# Altium schematic sheet CPLD-V MAIN.SchDoc
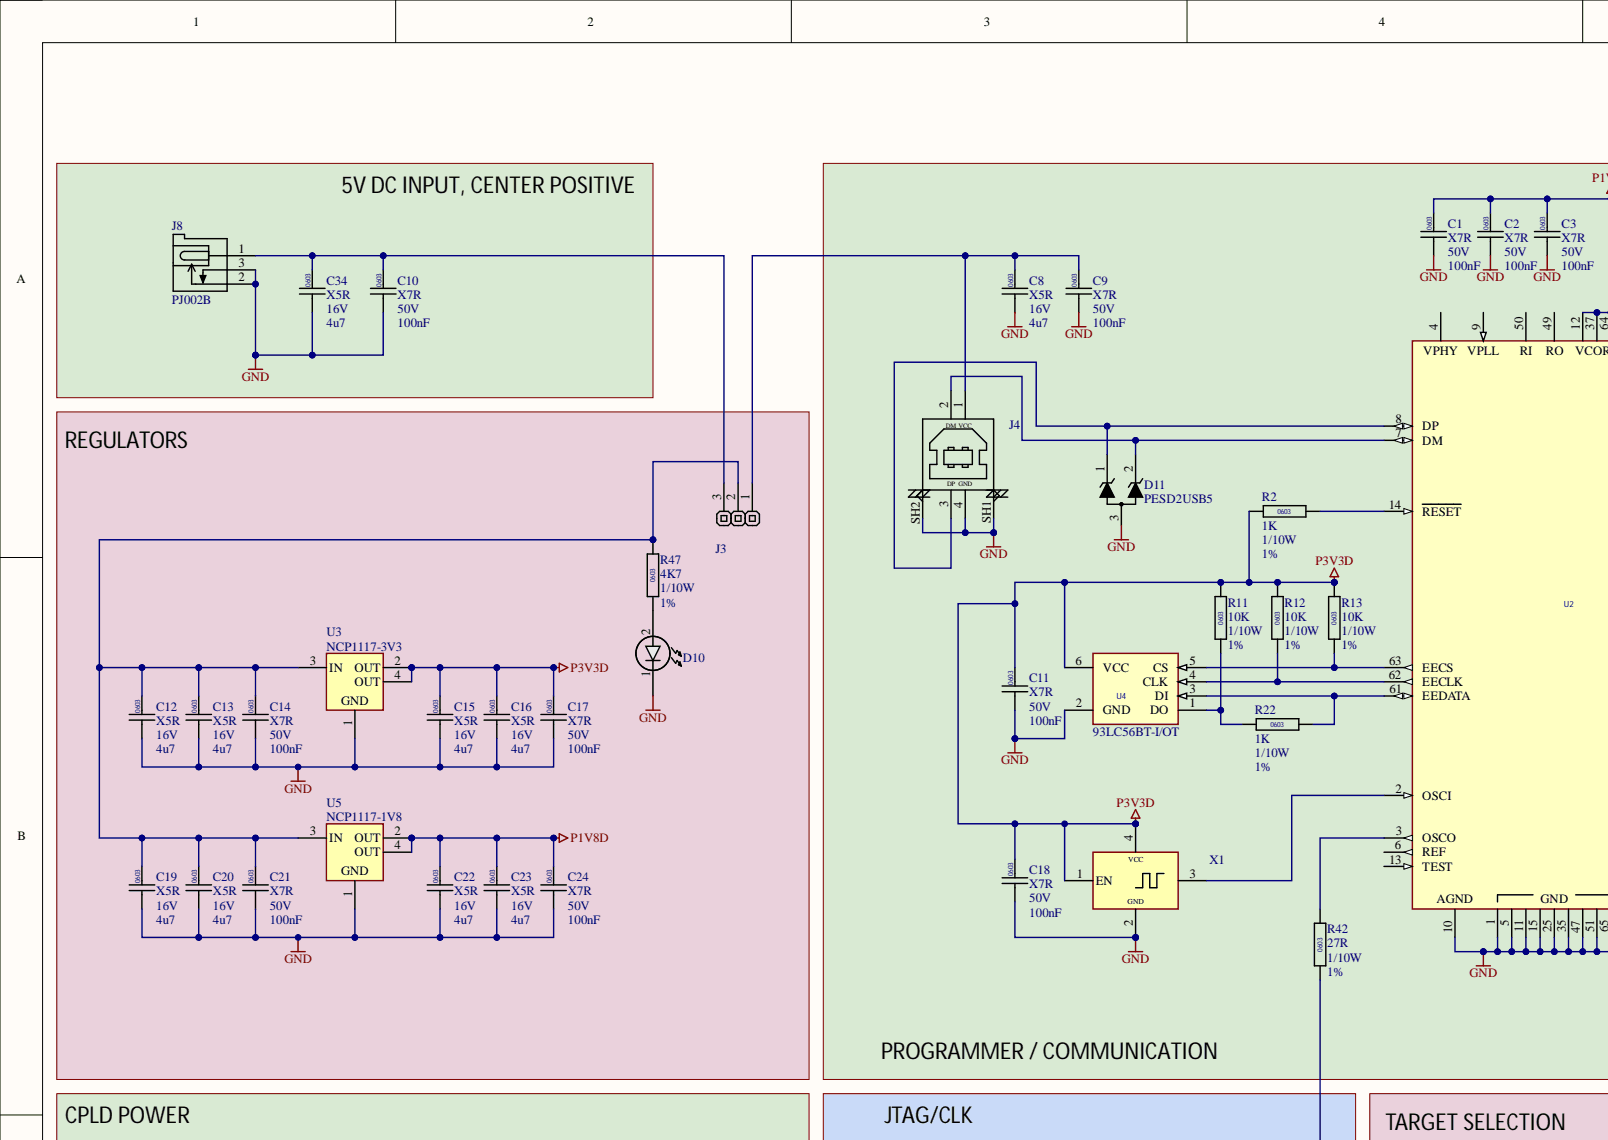
[diagram p0: CPLD-V MAIN.SchDoc, top left]
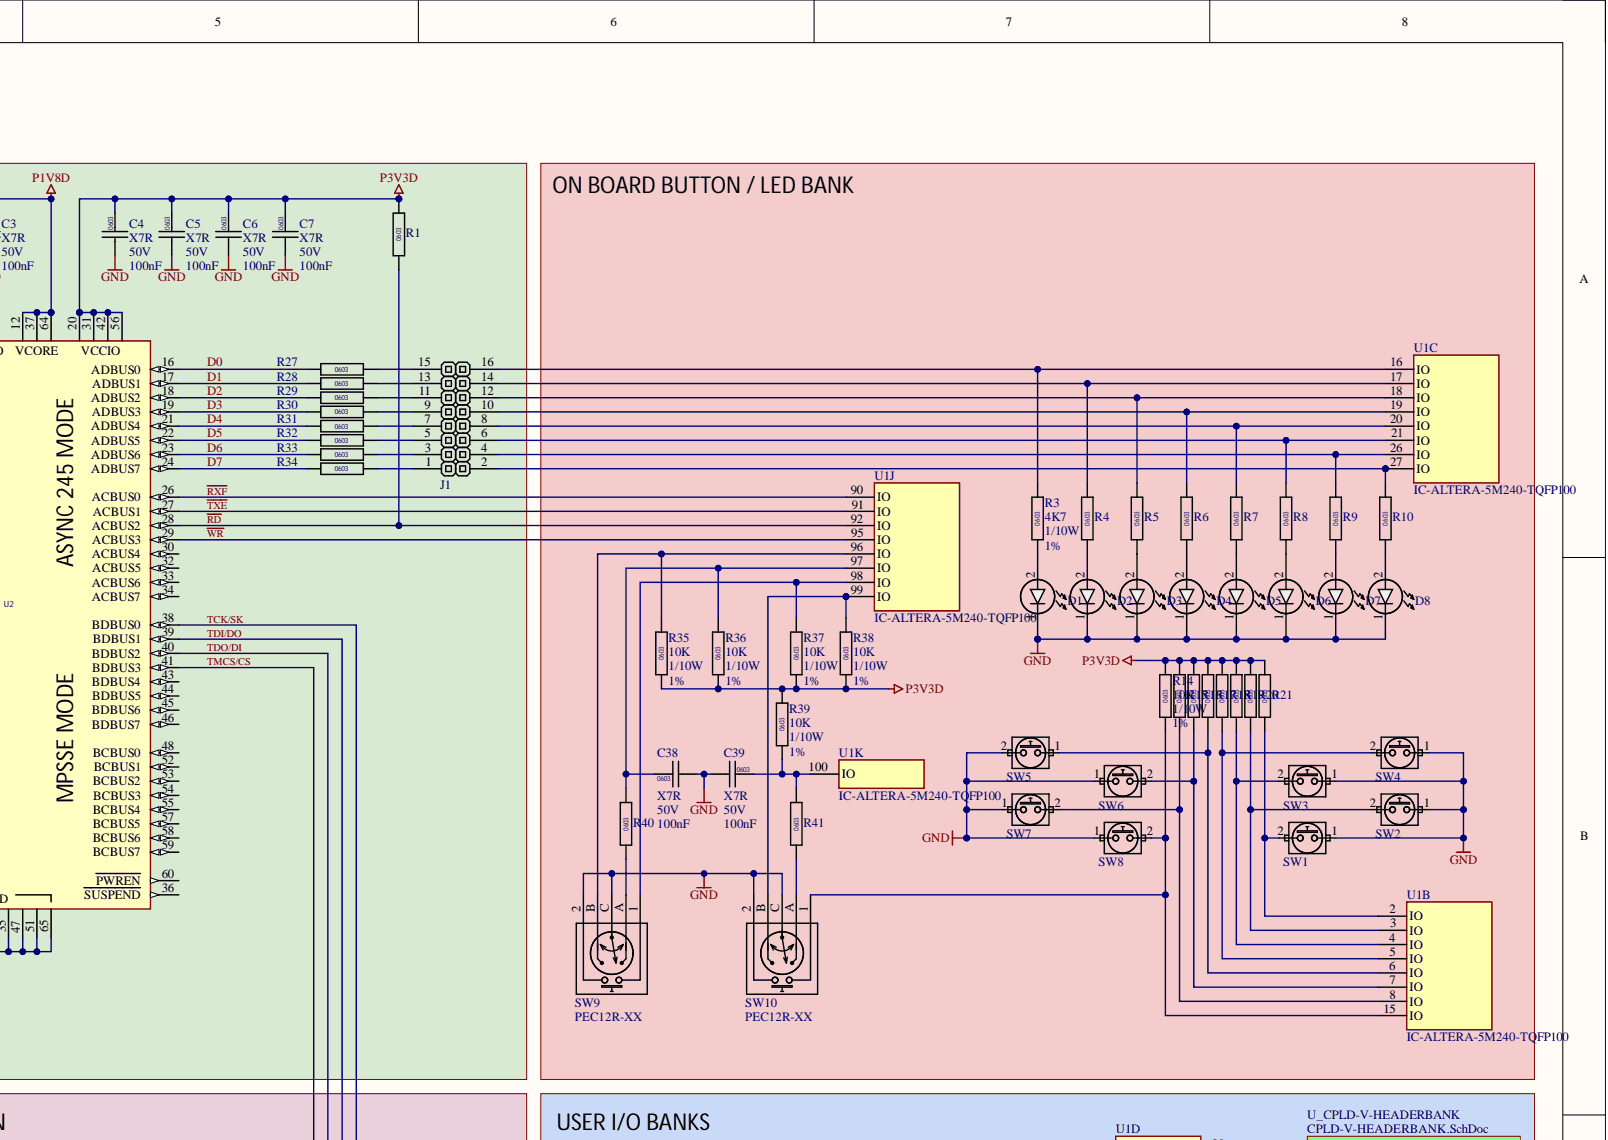
[diagram p1: CPLD-V MAIN.SchDoc, top right]
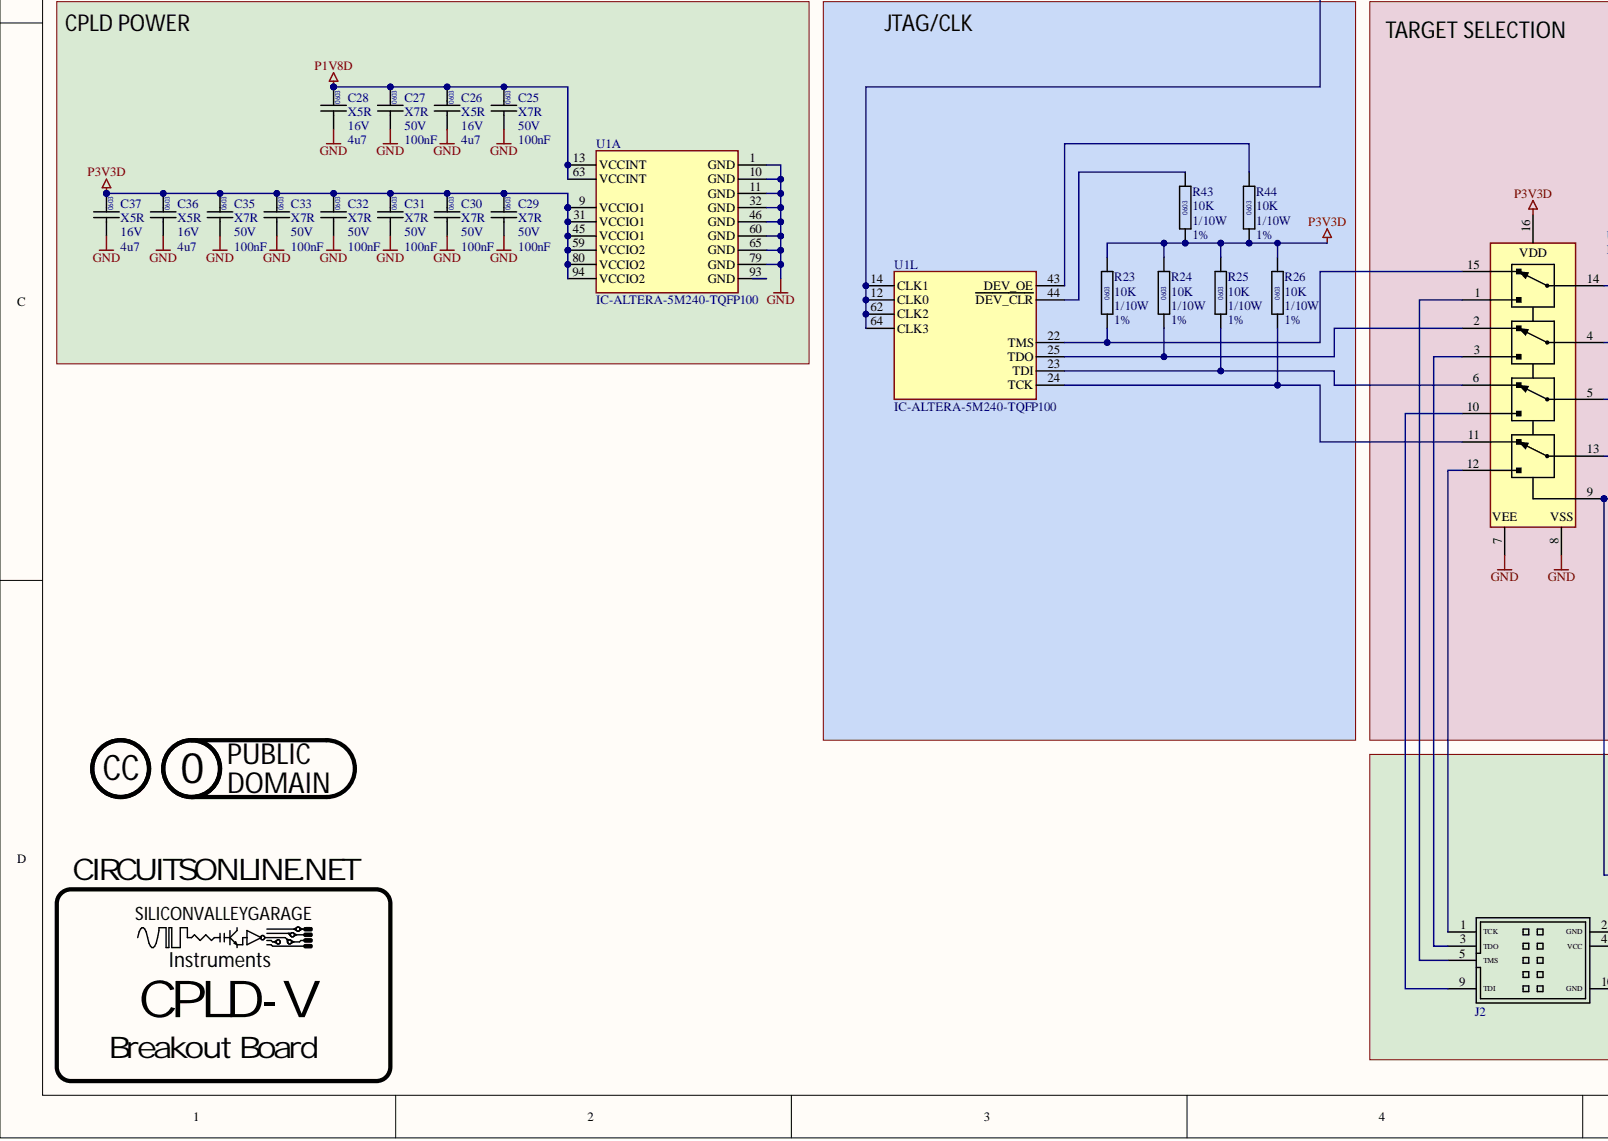
[diagram p2: CPLD-V MAIN.SchDoc, bottom left]
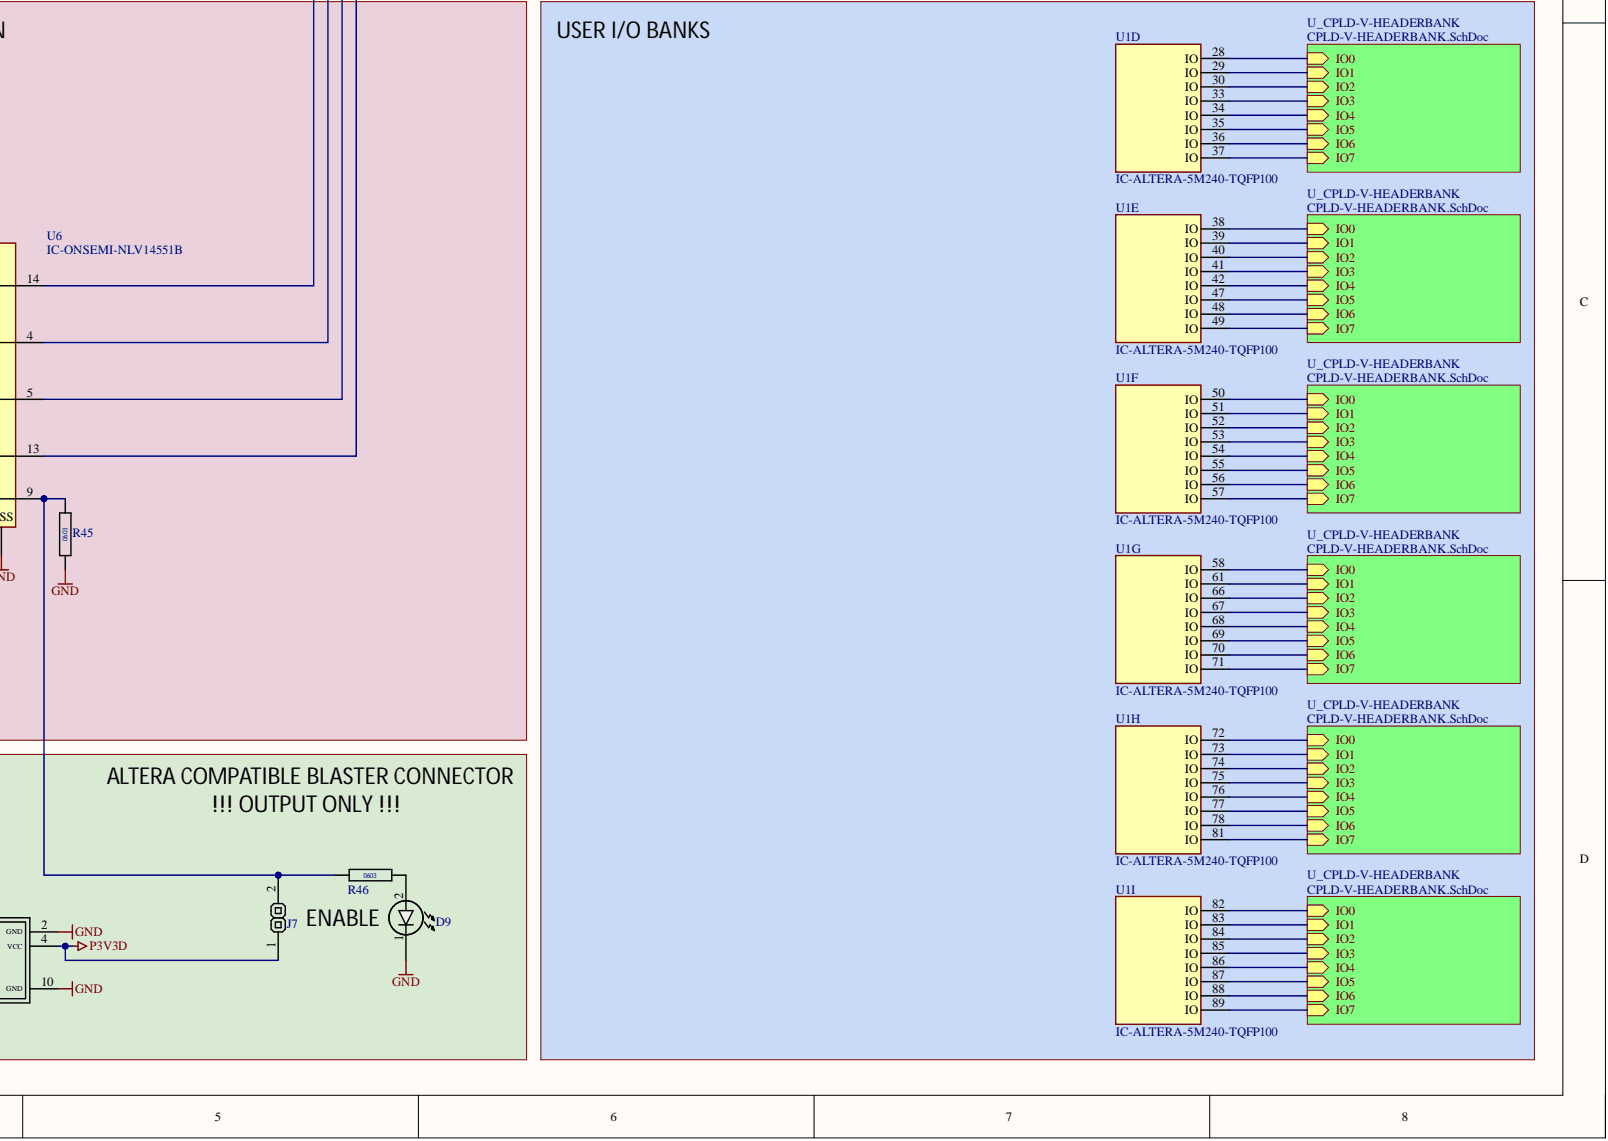
[diagram p3: CPLD-V MAIN.SchDoc, bottom right]

## Parts
C1  Capacitance: 100nF  Dielectric: X7R  Package / Case: 0603  Voltage: 50V  [CAPC0603]  (hidden comment: CAP0603)
C2  Capacitance: 100nF  Dielectric: X7R  Package / Case: 0603  Voltage: 50V  [CAPC0603]  (hidden comment: CAP0603)
C3  Capacitance: 100nF  Dielectric: X7R  Package / Case: 0603  Voltage: 50V  [CAPC0603]  (hidden comment: CAP0603)
C4  Capacitance: 100nF  Dielectric: X7R  Package / Case: 0603  Voltage: 50V  [CAPC0603]  (hidden comment: CAP0603)
C5  Capacitance: 100nF  Dielectric: X7R  Package / Case: 0603  Voltage: 50V  [CAPC0603]  (hidden comment: CAP0603)
C6  Capacitance: 100nF  Dielectric: X7R  Package / Case: 0603  Voltage: 50V  [CAPC0603]  (hidden comment: CAP0603)
C7  Capacitance: 100nF  Dielectric: X7R  Package / Case: 0603  Voltage: 50V  [CAPC0603]  (hidden comment: CAP0603)
C8  Capacitance: 4u7  Dielectric: X5R  Package / Case: 0603  Voltage: 16V  [CAPC0603]  (hidden comment: CAP0603)
C9  Capacitance: 100nF  Dielectric: X7R  Package / Case: 0603  Voltage: 50V  [CAPC0603]  (hidden comment: CAP0603)
C10  Capacitance: 100nF  Dielectric: X7R  Package / Case: 0603  Voltage: 50V  [CAPC0603]  (hidden comment: CAP0603)
C11  Capacitance: 100nF  Dielectric: X7R  Package / Case: 0603  Voltage: 50V  [CAPC0603]  (hidden comment: CAP0603)
C12  Capacitance: 4u7  Dielectric: X5R  Package / Case: 0603  Voltage: 16V  [CAPC0603]  (hidden comment: CAP0603)
C13  Capacitance: 4u7  Dielectric: X5R  Package / Case: 0603  Voltage: 16V  [CAPC0603]  (hidden comment: CAP0603)
C14  Capacitance: 100nF  Dielectric: X7R  Package / Case: 0603  Voltage: 50V  [CAPC0603]  (hidden comment: CAP0603)
C15  Capacitance: 4u7  Dielectric: X5R  Package / Case: 0603  Voltage: 16V  [CAPC0603]  (hidden comment: CAP0603)
C16  Capacitance: 4u7  Dielectric: X5R  Package / Case: 0603  Voltage: 16V  [CAPC0603]  (hidden comment: CAP0603)
C17  Capacitance: 100nF  Dielectric: X7R  Package / Case: 0603  Voltage: 50V  [CAPC0603]  (hidden comment: CAP0603)
C18  Dielectric: X7R  Package / Case: 0603  Voltage: 50V  [CAPC0603]  (hidden comment: CAP0603)
C19  Capacitance: 4u7  Dielectric: X5R  Package / Case: 0603  Voltage: 16V  [CAPC0603]  (hidden comment: CAP0603)
C20  Capacitance: 4u7  Dielectric: X5R  Package / Case: 0603  Voltage: 16V  [CAPC0603]  (hidden comment: CAP0603)
C21  Capacitance: 100nF  Dielectric: X7R  Package / Case: 0603  Voltage: 50V  [CAPC0603]  (hidden comment: CAP0603)
C22  Capacitance: 4u7  Dielectric: X5R  Package / Case: 0603  Voltage: 16V  [CAPC0603]  (hidden comment: CAP0603)
C23  Capacitance: 4u7  Dielectric: X5R  Package / Case: 0603  Voltage: 16V  [CAPC0603]  (hidden comment: CAP0603)
C24  Capacitance: 100nF  Dielectric: X7R  Package / Case: 0603  Voltage: 50V  [CAPC0603]  (hidden comment: CAP0603)
C25  Capacitance: 100nF  Dielectric: X7R  Package / Case: 0603  Voltage: 50V  [CAPC0603]  (hidden comment: CAP0603)
C26  Capacitance: 4u7  Dielectric: X5R  Package / Case: 0603  Voltage: 16V  [CAPC0603]  (hidden comment: CAP0603)
C27  Capacitance: 100nF  Dielectric: X7R  Package / Case: 0603  Voltage: 50V  [CAPC0603]  (hidden comment: CAP0603)
C28  Capacitance: 4u7  Dielectric: X5R  Package / Case: 0603  Voltage: 16V  [CAPC0603]  (hidden comment: CAP0603)
C29  Capacitance: 100nF  Dielectric: X7R  Package / Case: 0603  Voltage: 50V  [CAPC0603]  (hidden comment: CAP0603)
C30  Capacitance: 100nF  Dielectric: X7R  Package / Case: 0603  Voltage: 50V  [CAPC0603]  (hidden comment: CAP0603)
C31  Capacitance: 100nF  Dielectric: X7R  Package / Case: 0603  Voltage: 50V  [CAPC0603]  (hidden comment: CAP0603)
C32  Capacitance: 100nF  Dielectric: X7R  Package / Case: 0603  Voltage: 50V  [CAPC0603]  (hidden comment: CAP0603)
C33  Capacitance: 100nF  Dielectric: X7R  Package / Case: 0603  Voltage: 50V  [CAPC0603]  (hidden comment: CAP0603)
C34  Capacitance: 4u7  Dielectric: X5R  Package / Case: 0603  Voltage: 16V  [CAPC0603]  (hidden comment: CAP0603)
C35  Capacitance: 100nF  Dielectric: X7R  Package / Case: 0603  Voltage: 50V  [CAPC0603]  (hidden comment: CAP0603)
C36  Capacitance: 4u7  Dielectric: X5R  Package / Case: 0603  Voltage: 16V  [CAPC0603]  (hidden comment: CAP0603)
C37  Capacitance: 4u7  Dielectric: X5R  Package / Case: 0603  Voltage: 16V  [CAPC0603]  (hidden comment: CAP0603)
C38  Dielectric: X7R  Package / Case: 0603  [CAPC0603]  (hidden comment: CAP0603)
C39  Capacitance: 100nF  Dielectric: X7R  Package / Case: 0603  Voltage: 50V  [CAPC0603]  (hidden comment: CAP0603)
D1  KT-0603W  [LED-0603-GREEN]  (value not drawn)
D2  KT-0603W  [LED-0603-GREEN]  (value not drawn)
D3  KT-0603W  [LED-0603-GREEN]  (value not drawn)
D4  KT-0603W  [LED-0603-GREEN]  (value not drawn)
D5  KT-0603W  [LED-0603-GREEN]  (value not drawn)
D6  KT-0603W  [LED-0603-GREEN]  (value not drawn)
D7  KT-0603W  [LED-0603-GREEN]  (value not drawn)
D8  KT-0603W  [LED-0603-GREEN]  (value not drawn)
D9  KT-0603W  [LED-0603-GREEN]  (value not drawn)
D10  KT-0603W  [LED-0603-GREEN]  (value not drawn)
D11  PESD2USB5  [SOT23]
J1  BOXHEADER-3X2  [CONNECTOR-GENERIC-PINHEADER-2X8]  (value not drawn)
J2  ALTERA  (value not drawn)
J3  BOXHEADER-2X4  [HEADER-GENERIC-MALE-1X3]  (value not drawn)
J4  CONN-CUI-UJ2-BH-BL1-TH  [CONN-CUI-UJ2-BH-BL1-TH]  (value not drawn)
J7  CONN-HEADER-MALE-1x2  [HEADER-GENERIC-MALE-1X3]  (value not drawn)
J8  PJ002B  [CONN-CUI-PJ-002B]
LOGO1  LOGO-LICENSE-CC0-PUBLIC  [LOGO-LICENSE-CC0-PUBLIC]  (value not drawn)  (no BOM)
LOGO2  LOGO-LICENSE-CC  [LOGO-LICENSE-CC]  (value not drawn)  (no BOM)
R1  RESC0603  [RESC0603]  (value not drawn)
R2  value 1K  Power: 1/10W  [RESC0603]  (hidden comment: RESC0603)
R3  value 4K7  Power: 1/10W  Tolerance: 1%  [RESC0603]  (hidden comment: RESC0603)
R4  Power: 1/10W  [RESC0603]  (hidden comment: RESC0603)
R5  RESC0603  [RESC0603]  (value not drawn)
R6  RESC0603  [RESC0603]  (value not drawn)
R7  RESC0603  [RESC0603]  (value not drawn)
R8  RESC0603  [RESC0603]  (value not drawn)
R9  RESC0603  [RESC0603]  (value not drawn)
R10  RESC0603  [RESC0603]  (value not drawn)
R11  value 10K  Power: 1/10W  Tolerance: 1%  [RESC0603]  (hidden comment: RESC0603)
R12  value 10K  Power: 1/10W  Tolerance: 1%  [RESC0603]  (hidden comment: RESC0603)
R13  value 10K  Power: 1/10W  Tolerance: 1%  [RESC0603]  (hidden comment: RESC0603)
R14  value 10K  Power: 1/10W  Tolerance: 1%  [RESC0603]  (hidden comment: RESC0603)
R15  value 10K  Power: 1/10W  Tolerance: 1%  [RESC0603]  (hidden comment: RESC0603)
R16  value 10K  Power: 1/10W  Tolerance: 1%  [RESC0603]  (hidden comment: RESC0603)
R17  Power: 1/10W  [RESC0603]  (hidden comment: RESC0603)
R18  RESC0603  [RESC0603]  (value not drawn)
R19  RESC0603  [RESC0603]  (value not drawn)
R20  RESC0603  [RESC0603]  (value not drawn)
R21  RESC0603  [RESC0603]  (value not drawn)
R22  value 1K  Power: 1/10W  Tolerance: 1%  [RESC0603]  (hidden comment: RESC0603)
R23  value 10K  Power: 1/10W  Tolerance: 1%  [RESC0603]  (hidden comment: RESC0603)
R24  value 10K  Power: 1/10W  Tolerance: 1%  [RESC0603]  (hidden comment: RESC0603)
R25  value 10K  Power: 1/10W  Tolerance: 1%  [RESC0603]  (hidden comment: RESC0603)
R26  value 10K  Power: 1/10W  Tolerance: 1%  [RESC0603]  (hidden comment: RESC0603)
R27  RESC0603  [RESC0603]  (value not drawn)
R28  RESC0603  [RESC0603]  (value not drawn)
R29  RESC0603  [RESC0603]  (value not drawn)
R30  RESC0603  [RESC0603]  (value not drawn)
R31  RESC0603  [RESC0603]  (value not drawn)
R32  RESC0603  [RESC0603]  (value not drawn)
R33  RESC0603  [RESC0603]  (value not drawn)
R34  RESC0603  [RESC0603]  (value not drawn)
R35  value 10K  Power: 1/10W  Tolerance: 1%  [RESC0603]  (hidden comment: RESC0603)
R36  value 10K  Power: 1/10W  Tolerance: 1%  [RESC0603]  (hidden comment: RESC0603)
R37  value 10K  Power: 1/10W  Tolerance: 1%  [RESC0603]  (hidden comment: RESC0603)
R38  value 10K  Power: 1/10W  Tolerance: 1%  [RESC0603]  (hidden comment: RESC0603)
R39  value 10K  Power: 1/10W  Tolerance: 1%  [RESC0603]  (hidden comment: RESC0603)
R40  RESC0603  [RESC0603]  (value not drawn)
R41  RESC0603  [RESC0603]  (value not drawn)
R42  value 27R  Power: 1/10W  Tolerance: 1%  [RESC0603]  (hidden comment: RESC0603)
R43  value 10K  Power: 1/10W  Tolerance: 1%  [RESC0603]  (hidden comment: RESC0603)
R44  value 10K  Power: 1/10W  Tolerance: 1%  [RESC0603]  (hidden comment: RESC0603)
R45  RESC0603  [RESC0603]  (value not drawn)
R46  RESC0603  [RESC0603]  (value not drawn)
R47  value 4K7  Power: 1/10W  Tolerance: 1%  [RESC0603]  (hidden comment: RESC0603)
SW1  SWITCH-XUNPU-TS-1088-AR02016  [SWITCH-XUNPU-TS-1088-AR02016]  (value not drawn)
SW2  SWITCH-XUNPU-TS-1088-AR02016  [SWITCH-XUNPU-TS-1088-AR02016]  (value not drawn)
SW3  SWITCH-XUNPU-TS-1088-AR02016  [SWITCH-XUNPU-TS-1088-AR02016]  (value not drawn)
SW4  SWITCH-XUNPU-TS-1088-AR02016  [SWITCH-XUNPU-TS-1088-AR02016]  (value not drawn)
SW5  SWITCH-XUNPU-TS-1088-AR02016  [SWITCH-XUNPU-TS-1088-AR02016]  (value not drawn)
SW6  SWITCH-XUNPU-TS-1088-AR02016  [SWITCH-XUNPU-TS-1088-AR02016]  (value not drawn)
SW7  SWITCH-XUNPU-TS-1088-AR02016  [SWITCH-XUNPU-TS-1088-AR02016]  (value not drawn)
SW8  SWITCH-XUNPU-TS-1088-AR02016  [SWITCH-XUNPU-TS-1088-AR02016]  (value not drawn)
SW9  PEC12R-XX  [SWITCH-BOURNS-PEC12R-4120F-S0012]
SW10  PEC12R-XX  [SWITCH-BOURNS-PEC12R-4120F-S0012]
U1  IC-ALTERA-5M240-TQFP100  [QFP50P1600X1600X160-100N]  (parts A,B,C,D,E,F,G,H,I,J,K,L)
U2  FT2232HQ  [QFP50P1000X1000X100-64N]  (value not drawn)
U3  NCP1117-3V3
U4  93LC56BT-I/OT
U5  NCP1117-1V8
U6  IC-ONSEMI-NLV14551B
X1  XOSC  [XTAL-ABRACON-ABM8-272-T3]  (value not drawn)

## Nets
GND: C1.2 C2.2 C3.2 C4.2 C5.2 C6.2 C7.2 C8.2 C9.2 C10.2 C11.2 C12.2 C13.2 C14.2 C15.2 C16.2 C17.2 C18.2 C19.2 C20.2 C21.2 C22.2 C23.2 C24.2 C25.2 C26.2 C27.2 C28.2 C29.2 C30.2 C31.2 C32.2 C33.2 C34.2 C35.2 C36.2 C37.2 C38.2 C39.2 D1.1 D2.1 D3.1 D4.1 D5.1 D6.1 D7.1 D8.1 D9.1 D10.1 D11.3 J2.2(GND) J2.10(GND) J4.4 J4.SH1 J4.SH2 J8.2 J8.3 R45.2 SW1.1 SW2.1 SW3.1 SW4.1 SW5.2 SW6.1 SW7.1 SW8.1 SW9.2 SW9.C SW10.2 SW10.C U1.1(GND) U1.10(GND) U1.11(GND) U1.32(GND) U1.46(GND) U1.60(GND) U1.65(GND) U1.79(GND) U2.1 U2.5 U2.10 U2.11 U2.15 U2.25 U2.35 U2.47 U2.51 U2.65 U3.1 U4.2(GND) U5.1 U6.7 U6.8 X1.2
P1V8D: C1.1 C2.1 C3.1 C22.1 C23.1 C24.1 C25.1 C26.1 C27.1 C28.1 U1.13(VCCINT) U1.63(VCCINT) U2.12 U2.37 U2.64 U5.2(OUT) U5.4(OUT)
P3V3D: C4.1 C5.1 C6.1 C7.1 C11.1 C15.1 C16.1 C17.1 C18.1 C29.1 C30.1 C31.1 C32.1 C33.1 C35.1 C36.1 C37.1 J2.4(VCC) J7.1 R1.1 R2.1 R11.1 R12.1 R13.1 R14.1 R15.1 R16.1 R17.1 R18.1 R19.1 R20.1 R21.1 R23.1 R24.1 R25.1 R26.1 R35.1 R36.1 R37.1 R38.1 R39.1 R43.2 R44.2 U1.9(VCCIO1) U1.31(VCCIO1) U1.45(VCCIO1) U1.59(VCCIO2) U1.80(VCCIO2) U1.94(VCCIO2) U2.20 U2.31 U2.42 U2.56 U3.2(OUT) U3.4(OUT) U4.6(VCC) U6.16 X1.1(EN) X1.4
D0: R27.1 U2.16(ADBUS0)
D1: R28.1 U2.17(ADBUS1)
D2: R29.1 U2.18(ADBUS2)
D3: R30.1 U2.19(ADBUS3)
D4: R31.1 U2.21(ADBUS4)
D5: R32.1 U2.22(ADBUS5)
D6: R33.1 U2.23(ADBUS6)
D7: R34.1 U2.24(ADBUS7)
~{RD}: R1.2 U1.92(IO) U2.28(ACBUS2)
~{RXF}: U1.90(IO) U2.26(ACBUS0)
~{TXE}: U1.91(IO) U2.27(ACBUS1)
TCK/SK: U2.38(BDBUS0) U6.13
TDI/DO: U2.39(BDBUS1) U6.5
TDO/DI: U2.40(BDBUS2) U6.4
TMCS/CS: U2.41(BDBUS3) U6.14
~{WR}: U1.95(IO) U2.29(ACBUS3)
NetC8_1: C8.1 C9.1 J3.1 J4.1
NetC10_1: C10.1 C34.1 J3.3 J8.1
NetC12_1: C12.1 C13.1 C14.1 C19.1 C20.1 C21.1 J3.2 R47.2 U3.3(IN) U5.3(IN)
NetC38_1: C38.1 R36.2 R40.2 U1.97(IO)
NetC39_1: C39.1 R39.2 R41.2 U1.100(IO)
NetD1_2: D1.2 R3.1
NetD2_2: D2.2 R4.1
NetD3_2: D3.2 R5.1
NetD4_2: D4.2 R6.1
NetD5_2: D5.2 R7.1
NetD6_2: D6.2 R8.1
NetD7_2: D7.2 R9.1
NetD8_2: D8.2 R10.1
NetD9_2: D9.2 R46.1
NetD10_2: D10.2 R47.1
NetD11_1: D11.1 J4.3(DP) U2.8(DP)
NetD11_2: D11.2 J4.2(DM) U2.7(DM)
NetJ1_1: J1.1 R34.2
NetJ1_2: J1.2 R10.2 U1.27(IO)
NetJ1_3: J1.3 R33.2
NetJ1_4: J1.4 R9.2 U1.26(IO)
NetJ1_5: J1.5 R32.2
NetJ1_6: J1.6 R8.2 U1.21(IO)
NetJ1_7: J1.7 R31.2
NetJ1_8: J1.8 R7.2 U1.20(IO)
NetJ1_9: J1.9 R30.2
NetJ1_10: J1.10 R6.2 U1.19(IO)
NetJ1_11: J1.11 R29.2
NetJ1_12: J1.12 R5.2 U1.18(IO)
NetJ1_13: J1.13 R28.2
NetJ1_14: J1.14 R4.2 U1.17(IO)
NetJ1_15: J1.15 R27.2
NetJ1_16: J1.16 R3.2 U1.16(IO)
NetJ2_1: J2.1(TCK) U6.12
NetJ2_3: J2.3(TDO) U6.3
NetJ2_5: J2.5(TMS) U6.1
NetJ2_9: J2.9(TDI) U6.10
NetJ7_2: J7.2 R45.1 R46.2 U6.9
NetR2_2: R2.2 U2.14(RESET)
NetR11_2: R11.2 R22.1 U4.1(DO)
NetR12_2: R12.2 U2.62(EECLK) U4.4(CLK)
NetR13_2: R13.2 U2.63(EECS) U4.5(CS)
NetR14_2: R14.2 SW8.2 SW10.1 U1.15(IO)
NetR15_2: R15.2 SW7.2 U1.8(IO)
NetR16_2: R16.2 SW6.2 U1.7(IO)
NetR17_2: R17.2 SW5.1 U1.6(IO)
NetR18_2: R18.2 SW4.2 U1.5(IO)
NetR19_2: R19.2 SW3.2 U1.4(IO)
NetR20_2: R20.2 SW2.2 U1.3(IO)
NetR21_2: R21.2 SW1.2 U1.2(IO)
NetR22_2: R22.2 U2.61(EEDATA) U4.3(DI)
NetR23_2: R23.2 U1.22(TMS) U6.15
NetR24_2: R24.2 U1.25(TDO) U6.2
NetR25_2: R25.2 U1.23(TDI) U6.6
NetR26_2: R26.2 U1.24(TCK) U6.11
NetR35_2: R35.2 SW9.B U1.96(IO)
NetR37_2: R37.2 SW9.1 U1.98(IO)
NetR38_2: R38.2 SW10.B U1.99(IO)
NetR40_1: R40.1 SW9.A
NetR41_1: R41.1 SW10.A
NetR42_1: R42.1 U2.3(OSCO)
NetR42_2: R42.2 U1.12(CLK0) U1.14(CLK1) U1.62(CLK2) U1.64(CLK3)
NetR43_1: R43.1 U1.44(DEV_CLR)
NetR44_1: R44.1 U1.43(DEV_OE)
NetU1_28: U1.28(IO)
NetU1_29: U1.29(IO)
NetU1_30: U1.30(IO)
NetU1_33: U1.33(IO)
NetU1_34: U1.34(IO)
NetU1_35: U1.35(IO)
NetU1_36: U1.36(IO)
NetU1_37: U1.37(IO)
NetU1_38: U1.38(IO)
NetU1_39: U1.39(IO)
NetU1_40: U1.40(IO)
NetU1_41: U1.41(IO)
NetU1_42: U1.42(IO)
NetU1_47: U1.47(IO)
NetU1_48: U1.48(IO)
NetU1_49: U1.49(IO)
NetU1_50: U1.50(IO)
NetU1_51: U1.51(IO)
NetU1_52: U1.52(IO)
NetU1_53: U1.53(IO)
NetU1_54: U1.54(IO)
NetU1_55: U1.55(IO)
NetU1_56: U1.56(IO)
NetU1_57: U1.57(IO)
NetU1_58: U1.58(IO)
NetU1_61: U1.61(IO)
NetU1_66: U1.66(IO)
NetU1_67: U1.67(IO)
NetU1_68: U1.68(IO)
NetU1_69: U1.69(IO)
NetU1_70: U1.70(IO)
NetU1_71: U1.71(IO)
NetU1_72: U1.72(IO)
NetU1_73: U1.73(IO)
NetU1_74: U1.74(IO)
NetU1_75: U1.75(IO)
NetU1_76: U1.76(IO)
NetU1_77: U1.77(IO)
NetU1_78: U1.78(IO)
NetU1_81: U1.81(IO)
NetU1_82: U1.82(IO)
NetU1_83: U1.83(IO)
NetU1_84: U1.84(IO)
NetU1_85: U1.85(IO)
NetU1_86: U1.86(IO)
NetU1_87: U1.87(IO)
NetU1_88: U1.88(IO)
NetU1_89: U1.89(IO)
NetU1_93: U1.93(GND)
NetU2_2: U2.2(OSCI) X1.3
NetU2_4: U2.4
NetU2_6: U2.6(REF)
NetU2_9: U2.9
NetU2_13: U2.13(TEST)
NetU2_30: U2.30(ACBUS4)
NetU2_32: U2.32(ACBUS5)
NetU2_33: U2.33(ACBUS6)
NetU2_34: U2.34(ACBUS7)
NetU2_36: U2.36(SUSPEND)
NetU2_43: U2.43(BDBUS4)
NetU2_44: U2.44(BDBUS5)
NetU2_45: U2.45(BDBUS6)
NetU2_46: U2.46(BDBUS7)
NetU2_48: U2.48(BCBUS0)
NetU2_49: U2.49(RO)
NetU2_50: U2.50(RI)
NetU2_52: U2.52(BCBUS1)
NetU2_53: U2.53(BCBUS2)
NetU2_54: U2.54(BCBUS3)
NetU2_55: U2.55(BCBUS4)
NetU2_57: U2.57(BCBUS5)
NetU2_58: U2.58(BCBUS6)
NetU2_59: U2.59(BCBUS7)
NetU2_60: U2.60(PWREN)

## Library data of the parts
C1-C7 C9-C11 C14 C17 C18 C21 C24 C25 C27 C29-C33 C35 C38 C39 = CAPC-0603-100nF-50V-X7R: CAPACITOR,CERAMIC,100nF,50V,X7R,0603; Manufacturer 1: KEMET; Manufacturer Part Number 1: C0603C104K5RACTU; Component Kind: Standard; LCSC: C14663; Library Name: CAPACITORS.SchLib; MOUSER: 80-C0603C104K5R
  C18: Capacitance: 100nF
  C38: Capacitance: 100nF; Voltage: 50V
C8 C12 C13 C15 C16 C19 C20 C22 C23 C26 C28 C34 C36 C37 = CAPC-0603-4u7-10V-X5R_1: CAPACITOR,CERAMIC,4u7,16V,X5R,0603; Component Kind: Standard; LCSC: C19666; Library Name: CAPACITORS.SchLib
D1-D10 = LED-0603-WHITE: LED,SMD,WHITE,42mCd,0603; Manufacturer 1: Hubei KENTO Elec; Component Kind: Standard; LCSC: C2290; Library Name: LED.SchLib; Manufacturer Part Number 1: KT-0603W
D11 = TVS-NEXPERIA-PESD2USB5UX-TR: DIODE,TVS,DUAL,NIDIRETIONAL,USB,PESD2USB5UX-TR,SOT23; Component Kind: Standard; DIGIKEY: 1727-PESD2USB5UX-CT-ND; LCSC: C3709087; Library Name: DIODES.SchLib; Manufacturer 1: NEXPERIA; Manufacturer Part Number 1: PESD2USB5UX-TR
J1 = CONN-HEADER-MALE-2X8: CONN,HEADER,2X8,2.54mm; Component Kind: Standard; LCSC: C42431826; Library Name: CONNECTORS.SchLib
J2 = CONN-BOXHEADER-5X2-ALTERA: CONN,BOXHEADER,2X5,ALTERA JTAG PINOUT; Component Kind: Standard; Library Name: CONNECTORS.SchLib
J3 = CONN-HEADER-MALE-1x3: CONN,HEADER,1x3,2.54mm; Component Kind: Standard; LCSC: C429954; Library Name: CONNECTORS.SchLib
J4 = CONN-CUI-UJ2-BH-BL1-TH: CONN,USB2.0,B,RA,BLUE; Component Kind: Standard; LCSC: C720549; Library Name: CONNECTORS.SchLib
J7 = CONN-HEADER-MALE-1x2: CONN,HEADER,1x2,2.54mm; Component Kind: Standard; LCSC: C429954; Library Name: CONNECTORS.SchLib
J8 = CONN-CUI-PJ002B: CONN,DCPOWER,BARREL,CUI,PJ002B; Component Kind: Standard; Library Name: CONNECTORS.SchLib
R1 R18-R21 R45 = RESC-0603-10K-1%-1/10W: RESISTOR,10K,1%,100mW,0603 (1608); Manufacturer 1: YAGEO; Manufacturer Part Number 1: RC0603FR-0710KL; Power: 1/10W; Component Kind: Standard; LCSC: C25804; Library Name: RESISTORS.SchLib; MOUSER: 603-RC0603FR-0710KL; Tolerance: 1%; Value: 10K
R2 R22 = RESC-0603-1K-1%-1/10W: RESISTOR,1K,1%,100mW,0603 (1608); Manufacturer 1: YAGEO; Manufacturer Part Number 1: RC0603FR-071KL; Component Kind: Standard; LCSC: C21190; Library Name: RESISTORS.SchLib; MOUSER: 603-RC0603FR-071KL
  R2: Tolerance: 1%
R3 R4 R47 = RESC-0603-4K7-1%-1/10W: RESISTOR,4K7,1%,100mW,0603 (1608); Manufacturer 1: YAGEO; Manufacturer Part Number 1: RC0603FR-074K7L; Component Kind: Standard; LCSC: C23162; Library Name: RESISTORS.SchLib; MOUSER: 603-RC0603FR-074K7L
  R4: Tolerance: 1%; Value: 4K7
R5-R10 R46 = RESC-0603-4K7-1%-1/10W: RESISTOR,4K7,1%,100mW,0603 (1608); Manufacturer 1: YAGEO; Manufacturer Part Number 1: RC0603FR-074K7L; Power: 1/10W; Component Kind: Standard; LCSC: C23162; Library Name: RESISTORS.SchLib; MOUSER: 603-RC0603FR-074K7L; Tolerance: 1%; Value: 4K7
R11-R17 R23-R26 R35-R39 R43 R44 = RESC-0603-10K-1%-1/10W: RESISTOR,10K,1%,100mW,0603 (1608); Manufacturer 1: YAGEO; Manufacturer Part Number 1: RC0603FR-0710KL; Component Kind: Standard; LCSC: C25804; Library Name: RESISTORS.SchLib; MOUSER: 603-RC0603FR-0710KL
  R17: Tolerance: 1%; Value: 10K
R27-R34 R40 R41 = RESC-0603-470R-1%-1/10W: RESISTOR,470R,1%,100mW,0603 (1608); Power: 1/10W; Component Kind: Standard; LCSC: C23179; Library Name: RESISTORS.SchLib; Tolerance: 1%; Value: 470R
R42 = RESC-0603-27R-1%-1/10W_1: RESISTOR,27R,1%,100mW,0603 (1608); Component Kind: Standard; Library Name: RESISTORS.SchLib
SW1-SW8 = SWITCH-XUNPU-TS-1088-AR02016: SWITCH,TACT,SMD,2PIN,SMT,TOP ACTUATOR; Manufacturer 1: XUNPU; Component Kind: Standard; LCSC: C720477; Library Name: SWITCH.SchLib; Manufacturer Part Number 1: TS-1088-AR02016
SW9 SW10 = SWITCH-BOURNS-PEC12R-4120F-S0012: SWITCH,ENCODER+CLICK,BOURNS,PEC12R-4120F-S0012; Component Kind: Standard; Library Name: SWITCH.SchLib; Manufacturer 1: BOURNS; Manufacturer Part Number 1: PEC12R-4120F-S0012
U1 = IC-ALTERA-5M240-TQFP100: IC,LOGIC,CPLD,ALTERA,5M240-TQFP100; Component Kind: Standard; Library Name: IC-MEMORY.SchLib
U2 = IC-FTDI-FT2232HQ: IC,XCVR.USB USART.DUAL,FTDI,FT2232H,QFP64; Component Kind: Standard; LCSC: C193662; Library Name: IC-XCVR.SchLib
U3 = IC-ONSEMI-NCP1117-3V3: IC,VOLTAGE REG,LIN,POSITIVE,3V3,SOT223; Component Kind: Standard; Library Name: IC-REG.SchLib
U4 = IC-MICROCHIPIC-93LC56-SOT26: IC,EEPROM,2KBIT,MICROWIRE; Component Kind: Standard; LCSC: C190271; Library Name: IC-MEMORY.SchLib; P/N: 93LC56BT-I/OT
U5 = IC-ONSEMI-NCP1117-1V8: IC,VOLTAGE REG,LIN,POSITIVE,1V8,SOT223; Component Kind: Standard; Library Name: IC-REG.SchLib
U6 = IC-ONSEMI-NLV14551B: IC,ANALOG,4PDT ANALOG SWITCH,ONSEMI,NLV14551; Component Kind: Standard; Library Name: IC-ANALOG.SchLib
X1 = XOSC-JLYE-Y322512MNCCZ: XOSC,12MHz,3225; Manufacturer 1: JLYE; Component Kind: Standard; LCSC: C49207924; Library Name: XTAL.SchLib; Manufacturer Part Number 1: Y322512MNCCZ
LOGO1 = LOGO-LICENSE-CC0-PUBLIC: Library Name: LOGO.SCHLIB
LOGO2 = LOGO-LICENSE-CC: Library Name: LOGO.SCHLIB
